# Altium schematic sheet lv_signal_link.SchDoc(lv_signal_link)
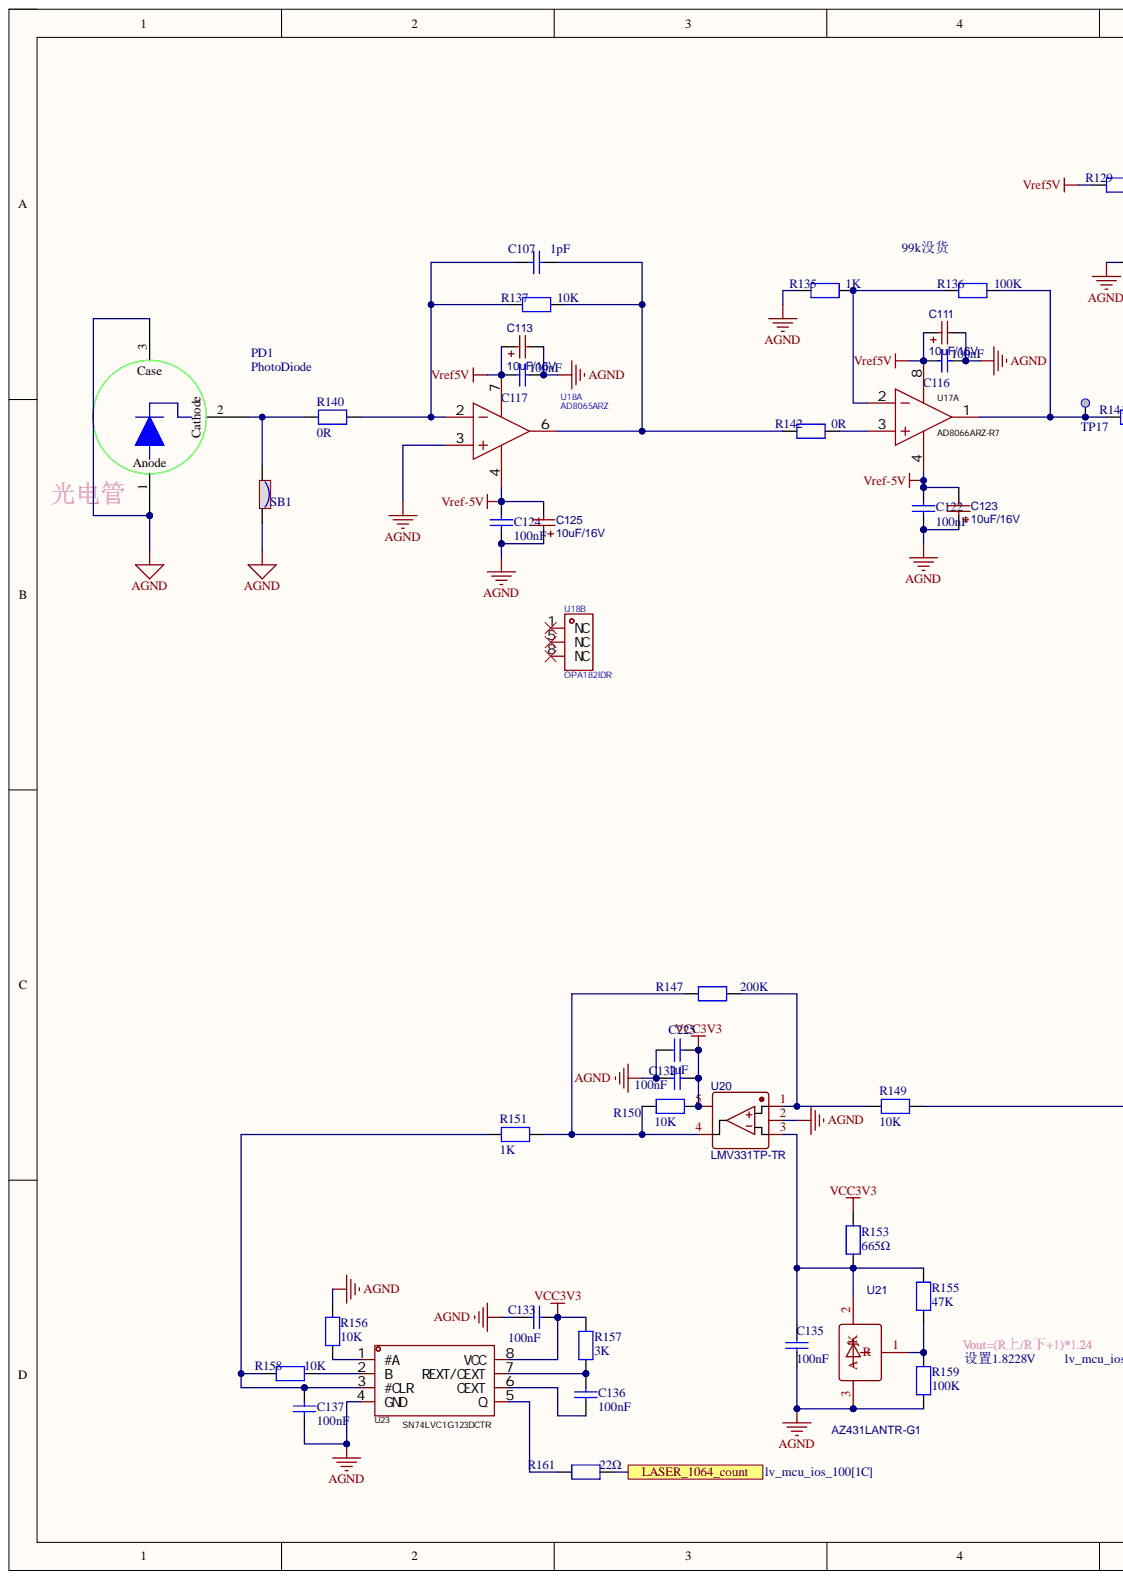
[diagram p0: lv_signal_link.SchDoc, left]
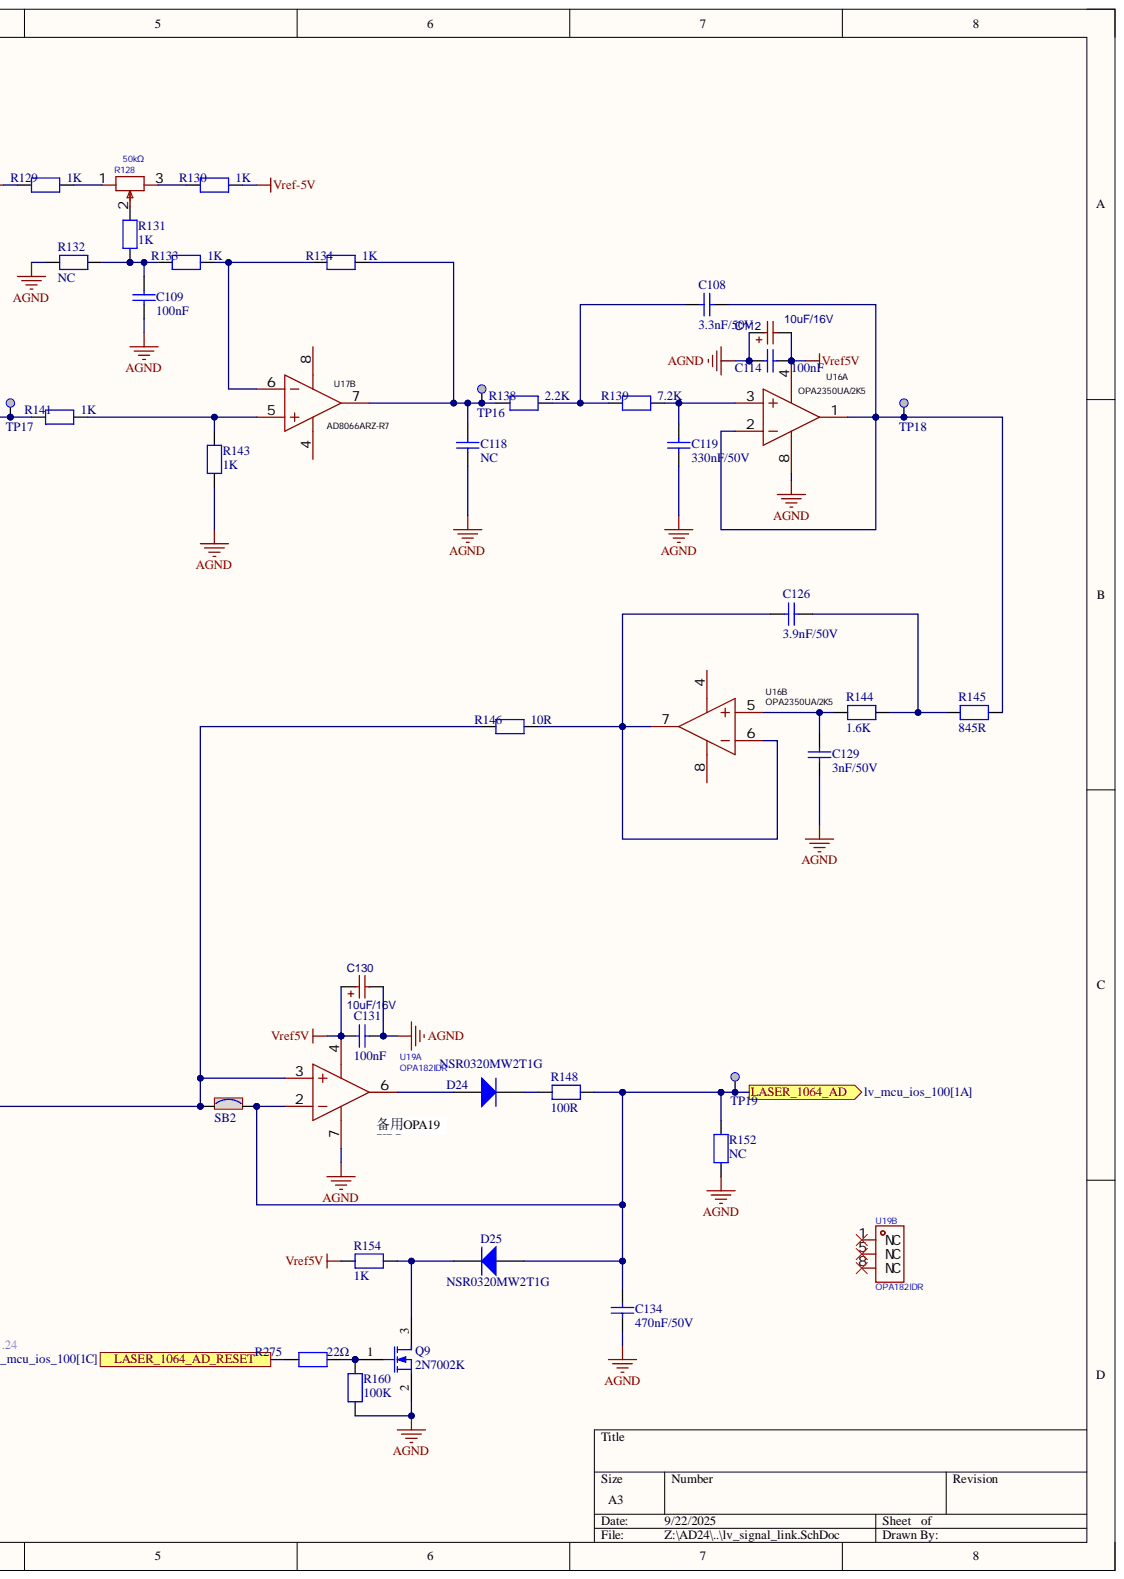
[diagram p1: lv_signal_link.SchDoc, right]

## Parts
C107  1pF  [C0603]
C108  3.3nF/50V  [C0603]
C109  100nF  [C0402]
C111  10uF/16V  [CAP-SMD_L3.2-W1.6-RD-C7171]
C112  10uF/16V  [CAP-SMD_L3.2-W1.6-RD-C7171]
C113  10uF/16V  [CAP-SMD_L3.2-W1.6-RD-C7171]
C114  100nF  [C0402]
C116  100nF  [C0402]
C117  100nF  [C0402]
C118  NC  [C0603]
C119  330nF/50V  [C0603]
C122  100nF  [C0402]
C123  10uF/16V  [CAP-SMD_L3.2-W1.6-RD-C7171]
C124  100nF  [C0402]
C125  10uF/16V  [CAP-SMD_L3.2-W1.6-RD-C7171]
C126  3.9nF/50V  [C0603]
C129  3nF/50V  [C0603]
C130  10uF/16V  [CAP-SMD_L3.2-W1.6-RD-C7171]
C131  100nF  [C0402]
C132  100nF  [C0402]
C133  100nF  [C0402]
C134  470nF/50V  [C0603]
C135  100nF  [C0402]
C136  100nF  [C1210]
C137  100nF  [C0402]
C225  value 1uF  [C0603]
D24  NSR0320MW2T1G  [DIO_SOD323]
D25  NSR0320MW2T1G  [DIO_SOD323]
PD1  PhotoDiode  [photodiode1064]
Q9  2N7002K  [SOT-23(SOT-23-3)]
R128  50kΩ  [RES-ADJ-SMD_3224W]
R129  1K  [R0603]
R130  1K  [R0603]
R131  1K  [R0603]
R132  NC  [R0603]
R133  1K  [R0603]
R134  1K  [R0603]
R135  1K  [R0603]
R136  100K  [R0603]
R137  10K  [R0603]
R138  2.2K  [R0603]
R139  7.2K  [R0603]
R140  0R  [R0402]
R141  1K  [R0603]
R142  0R  [R0603]
R143  1K  [R0603]
R144  1.6K  [R0603]
R145  845R  [R0603]
R146  10R  [R0603]
R147  200K  [R0402]
R148  100R  [R0402]
R149  10K  [R0402]
R150  10K  [R0402]
R151  1K  [R0402]
R152  NC  [R0402]
R153  665Ω  [R0402]
R154  1K  [R0402]
R155  47K  [R0402]
R156  10K  [R0402]
R157  3K  [R0603]
R158  10K  [R0402]
R159  100K  [R0402]
R160  100K  [R0402]
R161  22Ω  [R0402]
R275  22Ω  [R0402]
SB1  SB_ON  [SOLDER-BRIDGE_R0603-0R]  (value not drawn)
SB2  SB_ON  [SOLDER-BRIDGE_R0603-0R]  (value not drawn)
TP16  Test Point  [TP_SMD_1MM]  (value not drawn)  (no BOM)
TP17  Test Point  [TP_SMD_1MM]  (value not drawn)  (no BOM)
TP18  Test Point  [TP_SMD_1MM]  (value not drawn)  (no BOM)
TP19  Test Point  [TP_SMD_1MM]  (value not drawn)  (no BOM)
U16  OPA2350UA/2K5  [SOIC-8_L4.9-W3.9-P1.27-LS6.0-BL]  (parts A,B)
U17  AD8066ARZ-R7  [SOIC-8_L4.9-W3.9-P1.27-LS6.0-BL]  (parts A,B)
U18  AD8065ARZ  [SOIC-8_L4.9-W3.9-P1.27-LS6.0-BL]  (parts A,B)
U19  OPA182IDR  [SOIC-8_L4.9-W3.9-P1.27-LS6.0-BL]  (parts A,B)
U20  LMV331TP-TR  [SOT-23-5_L3.0-W1.7-P0.95-LS2.8-BR]
U21  AZ431LANTR-G1  [SOT-23-3_L2.9-W1.6-P1.90-LS2.8-BR]
U23  SN74LVC1G123DCTR  [SM8_L3.2-W2.9-P0.65-LS4.3-BR]

## Ports
LASER_1064_AD, LASER_1064_AD_RESET, LASER_1064_count

## Nets
AGND: C109.2 C111.2 C112.1 C113.2 C114.2 C116.2 C117.2 C118.1 C119.1 C122.2 C123.1 C124.2 C125.1 C129.1 C130.2 C131.2 C132.2 C133.2 C134.1 C135.2 C137.1 C225.1 PD1.1 PD1.3 Q9.2 R132.1 R135.2 R143.2 R152.1 R156.2 R159.1 R160.1 SB1.2 U16.8 U18.3 U19.7 U20.2 U21.3 U23.4(GND)
VCC3V3: C132.1 C133.1 C225.2 R150.2 R153.2 R157.2 U20.5 U23.8(VCC)
Vref5V: C111.1 C112.2 C113.1 C114.1 C116.1 C117.1 C130.1 C131.1 R129.2 R154.1 U16.4 U17.8 U18.7 U19.4
Vref-5V: C122.1 C123.2 C124.1 C125.2 R130.1 U17.4 U18.4
LASER_1064_AD: C134.2 D25.1 R148.2 R152.2 SB2.2 TP19.1 U19.2 ; port LASER_1064_AD
LASER_1064_AD_RESET: R275.2 ; port LASER_1064_AD_RESET
LASER_1064_count: R161.1 ; port LASER_1064_count
NetC107_1: C107.1 R137.2 R140.2 U18.2
NetC107_2: C107.2 R137.1 R142.2 U18.6
NetC108_1: C108.1 R145.2 TP18.1 U16.1 U16.2
NetC108_2: C108.2 R138.1 R139.2
NetC109_1: C109.1 R131.2 R132.2 R133.2
NetC118_2: C118.2 R134.1 R138.2 TP16.1 U17.7
NetC119_2: C119.2 R139.1 U16.3
NetC126_1: C126.1 R146.1 U16.6 U16.7
NetC126_2: C126.2 R144.2 R145.1
NetC129_2: C129.2 R144.1 U16.5
NetC135_1: C135.1 R153.1 R155.2 U20.3 U21.2
NetC136_1: C136.1 U23.6(CEXT)
NetC136_2: C136.2 R157.1 U23.7(REXT/CEXT)
NetC137_2: C137.2 R151.1 R158.2 U23.3(#CLR)
NetD24_1: D24.1 U19.6
NetD24_2: D24.2 R148.1
NetD25_2: D25.2 Q9.3 R154.2
NetPD1_2: PD1.2 R140.1 SB1.1
NetQ9_1: Q9.1 R160.2 R275.1
NetR128_1: R128.1 R129.1
NetR128_2: R128.2 R131.1
NetR128_3: R128.3 R130.2
NetR133_1: R133.1 R134.2 U17.6
NetR135_1: R135.1 R136.2 U17.2
NetR136_1: R136.1 R141.2 TP17.1 U17.1
NetR141_1: R141.1 R143.1 U17.5
NetR142_1: R142.1 U17.3
NetR146_2: R146.2 R149.1 SB2.1 U19.3
NetR147_1: R147.1 R149.2 U20.1
NetR147_2: R147.2 R150.1 R151.2 U20.4
NetR155_1: R155.1 R159.2 U21.1
NetR156_1: R156.1 U23.1(#A)
NetR158_1: R158.1 U23.2(B)
NetR161_2: R161.2 U23.5(Q)

Not connected: U18 1(NC) 5(NC) 8(NC); U19 1(NC) 5(NC) 8(NC)

## Library data of the parts
C107 = Capacitor_1: 16V, X5R, 10%; Internal_Part_Number: MCD-00009; Manufacturer: YAGEO; Manufacturer_Part_Number: CC0603BRNPO9BN1R0; Component Kind: Standard; ComponentLink1Description: Yageo_Capacitor_X5R-series.pdf; ComponentLink1URL: http://tunmcdsvn.tun.st.com/Component_Datasheet_Library/Trunk/Capacitors/Yageo_Multilayer_capacitor_X5R_series_100pF_to_100uF; Library Name: HiPin_Library_AD.IntLib; Package: C0603
C108 = Capacitor_1: 50V, COG, 10%; Internal_Part_Number: MCD-00009; Manufacturer: muRata; Manufacturer_Part_Number: GRM1885C1H332JA01D; Component Kind: Standard; ComponentLink1Description: Yageo_Capacitor_X5R-series.pdf; ComponentLink1URL: http://tunmcdsvn.tun.st.com/Component_Datasheet_Library/Trunk/Capacitors/Yageo_Multilayer_capacitor_X5R_series_100pF_to_100uF; Library Name: HiPin_Library_AD.IntLib; Package: C0603
C109 C114 C116 C117 C122 C124 C131 C132 C135 = Capacitor: 50V, 10%, X7R; Internal_Part_Number: MCD-00003; Manufacturer: muRata; Manufacturer_Part_Number: GRM155R71H104KE14D; Component Kind: Standard; ComponentLink1Description: Datasheet; ComponentLink1URL: http://tunmcdsvn.tun.st.com/Component_Datasheet_Library/Trunk/Capacitors/Yageo_Capacitor_NP0_16V-to-50V; Library Name: HiPin_Library_AD.IntLib; Package: C0402; Value: 100nF
C111-C113 C123 C125 C130 = 10uF: TA 0.8ohm,10%; Manufacturer: AVX; Manufacturer_Part_Number: TAJA106K016RNJ; Component Kind: Standard; Library Name: HiPin_Library_AD.IntLib
C118 = Capacitor_1: 16V, X5R, 10%; Internal_Part_Number: MCD-00009; Manufacturer: muRata; Manufacturer_Part_Number: GRM21BR61C106KE15L; Component Kind: Standard; ComponentLink1Description: Yageo_Capacitor_X5R-series.pdf; ComponentLink1URL: http://tunmcdsvn.tun.st.com/Component_Datasheet_Library/Trunk/Capacitors/Yageo_Multilayer_capacitor_X5R_series_100pF_to_100uF; Library Name: HiPin_Library_AD.IntLib; Package: C0603
C119 = Capacitor_1: 50V, C0G, 5%; Manufacturer: muRata; Manufacturer_Part_Number: GRM1885C1H332JA01D; Component Kind: Standard; Library Name: HiPin_Library_AD.IntLib; Package: C0603
C126 = Capacitor_1: 50V, C0G, 5%; Manufacturer: muRata; Manufacturer_Part_Number: GRM1885C1H392JA01D; Component Kind: Standard; Library Name: HiPin_Library_AD.IntLib
C129 = Capacitor_1: 50V,C0G, 5%; Manufacturer: muRata; Manufacturer_Part_Number: GRM1885C1H302JA01D; Component Kind: Standard; Library Name: HiPin_Library_AD.IntLib; Package: C0603
C133 = Capacitor_2: 50V, X5R, 10%; Internal_Part_Number: MCD-00014; Manufacturer: muRata; Manufacturer_Part_Number: GRM21BR61E226ME44L; Value: 22uF; Component Kind: Standard; ComponentLink1Description: Datasheet; ComponentLink1URL: http://tunmcdsvn.tun.st.com/Component_Datasheet_Library/Trunk/Capacitors/Yageo_Multilayer_capacitor_X5R_series_100pF_to_100uF; Library Name: HiPin_Library_AD.IntLib
C134 = Capacitor_1: 50V, X7R, 10%; Manufacturer: YAGEO; Manufacturer_Part_Number: CC0603KRX7R9BB474; Component Kind: Standard; Library Name: HiPin_Library_AD.IntLib
C136 C137 = Capacitor_3: 50V, C0G, 1%; Internal_Part_Number: MCD-00008; Manufacturer: muRata; Manufacturer_Part_Number: GRM31C5C1H104FA01L; Component Kind: Standard; Library Name: HiPin_Library_AD.IntLib
C225 = MCD-00010: Capacitor, MLCC, 1uF, 16V, X5R, 10%, 0603, T=0,8mm; Manufacturer: YAGEO; Manufacturer_Part_Number: CC0603KRX5R7BB105; Database Table Name: MCD_PARTS$; Initial_Board_Reference: MB1274; Provided_By: Subcon; Component Kind: Standard; ComponentLink1Description: Yageo_Capacitor_X5R-series.pdf; ComponentLink1URL: http://tunmcdsvn.tun.st.com/Component_Datasheet_Library/Trunk/Capacitors/Yageo_Multilayer_capacitor_X5R_series_100pF_to_100uF; ECCN_EU: n/a; ECCN_US: n/a; Internal_Part_Number: MCD-00010; Library Name: MCD_PARTS_database.DbLib; Package: C0603; Part_Type: Capacitor; Status: Released
D24 D25 = BAT60JFILM: 20V, 500mV, 1A; Manufacturer: onsemi(安森美); Component Kind: Standard; Library Name: HiPin_Library_AD.IntLib; Manufacturer_Part_Number: NSR0320MW2T1G
Q9 = MOSFET-N: N沟道,60V,0.115A,7Ω@10V; Manufactu: CJ江苏长电; ManufacturerNumber: 2N7002; Component Kind: Standard; Library Name: HiPin_Library_AD.IntLib; Package: SOT-23; SuppliersPartNumber: C8545
R128 = 3224W-1-103E: 可调电阻; Manufacturer: BOURNS; Manufacturer Part: 3224W-1-503E; Temperature Coefficient: ±100ppm/℃; Component Kind: Standard; Datasheet: https://atta.szlcsc.com/upload/public/pdf/source/20160830/1472551532733.pdf; Library Name: HiPin_Library_AD.IntLib; PCB3D: RES-ADJ-SMD_3224W
R129-R139 R141-R146 R149 R150 R156 R158 R161 R275 = RES: 1%; Component Kind: Standard; Library Name: HiPin_Library_AD.IntLib
  R149 R150 R156 R158: Value: 10K
R140 R147 R148 R151 R152 R154 R157 R160 = RES: 1%; Value: 10K; Component Kind: Standard; Library Name: HiPin_Library_AD.IntLib
R153 R155 R159 = RES: Resistor; Component Kind: Standard; Library Name: HiPin_Library_AD.IntLib
SB1 SB2 = SB_ON: Solder Bridge ON; Internal_Part_Number: MCD-00071; Manufacturer: YAGEO; Manufacturer_Part_Number: RC0603JR-070RL; Part_Type: Mechanical; Provided_By: SUBCO; Component Kind: Standard; ComponentLink1Description: Datasheet; ComponentLink1URL: http://tunmcdsvn.tun.st.com/Component_Datasheet_Library/Trunk/Resistors/Yageo_Resistor_RC0603-series; ECCN_EU: n/a; ECCN_US: n/a; Library Name: HiPin_Library_AD.IntLib; Package: SB0603; Status: Released
TP16-TP19 = MCD-00074: Test Point 1mm; Part_Type: Mechanical; Database Table Name: MCD_PARTS$; ComponentLink1Description: Datasheet; ComponentLink1URL: http://na; ECCN_EU: n/a; ECCN_US: n/a; Internal_Part_Number: MCD-00074; Library Name: MCD_PARTS_database.DbLib; Manufacturer: n/a; Manufacturer_Part_Number: n/a; Provided_By: n/a; Status: Released
U16 = OPA2170AIDR: Manufacturer: TI(德州仪器); Component Kind: Standard; Library Name: HiPin_Library_AD.IntLib; Manufacturer Part: OPA2350UA/2K5
U17 = OPA2170AIDR: Manufacturer: TI(德州仪器); Manufacturer Part: OPA2350UA/2K5; Component Kind: Standard; Library Name: HiPin_Library_AD.IntLib
U18 = OPA182IDR: FET输入运放，但路，145Mhz， 1pA; Manufacturer: ADI; Gain Bandwidth Product(GBP): 145MHz; Number of Circuits: 单路; Component Kind: Standard; Library Name: HiPin_Library_AD.IntLib; Manufacturer Part: AD8065ARZ; Operating Temperature: -40℃~+85℃; PCB3D: SOIC-8_L4.9-W3.9-H1.7-LS6.0-P1.27; Supplier Footprint: SOIC-8
U19 = OPA182IDR: 36V、5MHz、低噪声、零漂移、多路复用器友好型精密运算放大器; Manufacturer: TI(德州仪器); -3db Bandwidth(G=1): 3.6MHz; Add into BOM: yes; Current - Input Bias(Ib): 50pA; Gain Bandwidth Product(GBP): 5MHz; Number of Circuits: 单路; Slew Rate(SR): 10V/us; Voltage - Input Offset(Vos): 450nV; Component Kind: Standard; Convert to PCB: yes; Datasheet: https://www.ti.com/cn/lit/gpn/opa2182; Device: OPA182IDR; JLCPCB Part Class: Extended Part; LCSC Part Name: OPA182IDR; Library Name: HiPin_Library_AD.IntLib; Manufacturer Part: OPA182IDR; Operating Temperature: -40℃~+125℃; Output Type: -; PCB3D: SOIC-8_L4.9-W3.9-H1.7-LS6.0-P1.27; Rail to Rail: -; Supplier: LCSC; Supplier Footprint: SOIC-8; Supplier Part: C4371168; Symbol: OPA182IDR
U20 = LMV331TP-TR: 比较器; BOM_Manufacturer: 3PEAK; Contributor: LCSC; BOM_JLCPCB Part Class: Extended Part; BOM_Manufacturer Part: LMV331TP-TR; BOM_Supplier: LCSC; BOM_Supplier Part: C108095; Component Kind: Standard; Library Name: HiPin_Library_AD.IntLib; spicePre: U; spiceSymbolName: LMV331TP-TR
U21 = TL431: BOM_Manufacturer: JSMSEMI; Contributor: LCSC; BOM_JLCPCB Part Class: Extended Part; BOM_Manufacturer Part: TL431; BOM_Supplier: LCSC; BOM_Supplier Part: C963380; Component Kind: Standard; Library Name: HiPin_Library_AD.IntLib; spicePre: U; spiceSymbolName: TL431_C963380
U23 = SN74LVC1G123DCTR: Manufacturer: TI(德州仪器); Add into BOM: yes; Input Type: 施密特触发器; Logic Family: 74LVC; Logic Gate Type: 单稳态; Number Of Channels: 1; Propagation Delay: 18.5ns; Sink Current: 32mA; Supply Voltage: 1.65V~5.5V; Component Kind: Standard; Convert to PCB: yes; Datasheet: https://www.ti.com/cn/lit/gpn/sn74lvc1g123; Device: SN74LVC1G123DCTR; JLCPCB Part Class: Extended Part; LCSC Part Name: SN74LVC1G123DCTR; Library Name: HiPin_Library_AD.IntLib; Manufacturer Part: SN74LVC1G123DCTR; OperatingTemperature: -40℃~+125℃; PCB3D: SM8_L3.2-W2.9-H1.3-LS4.3-P0.65; Source Current: 32mA; Supplier: LCSC; Supplier Footprint: SM-8; Supplier Part: C123302; Symbol: SN74LVC1G123DCTR
PD1 = PhotoDiode: Component Kind: Standard; Library Name: HiPin_Library_AD.IntLib
